# Altium schematic sheet Resistive 1.SchDoc
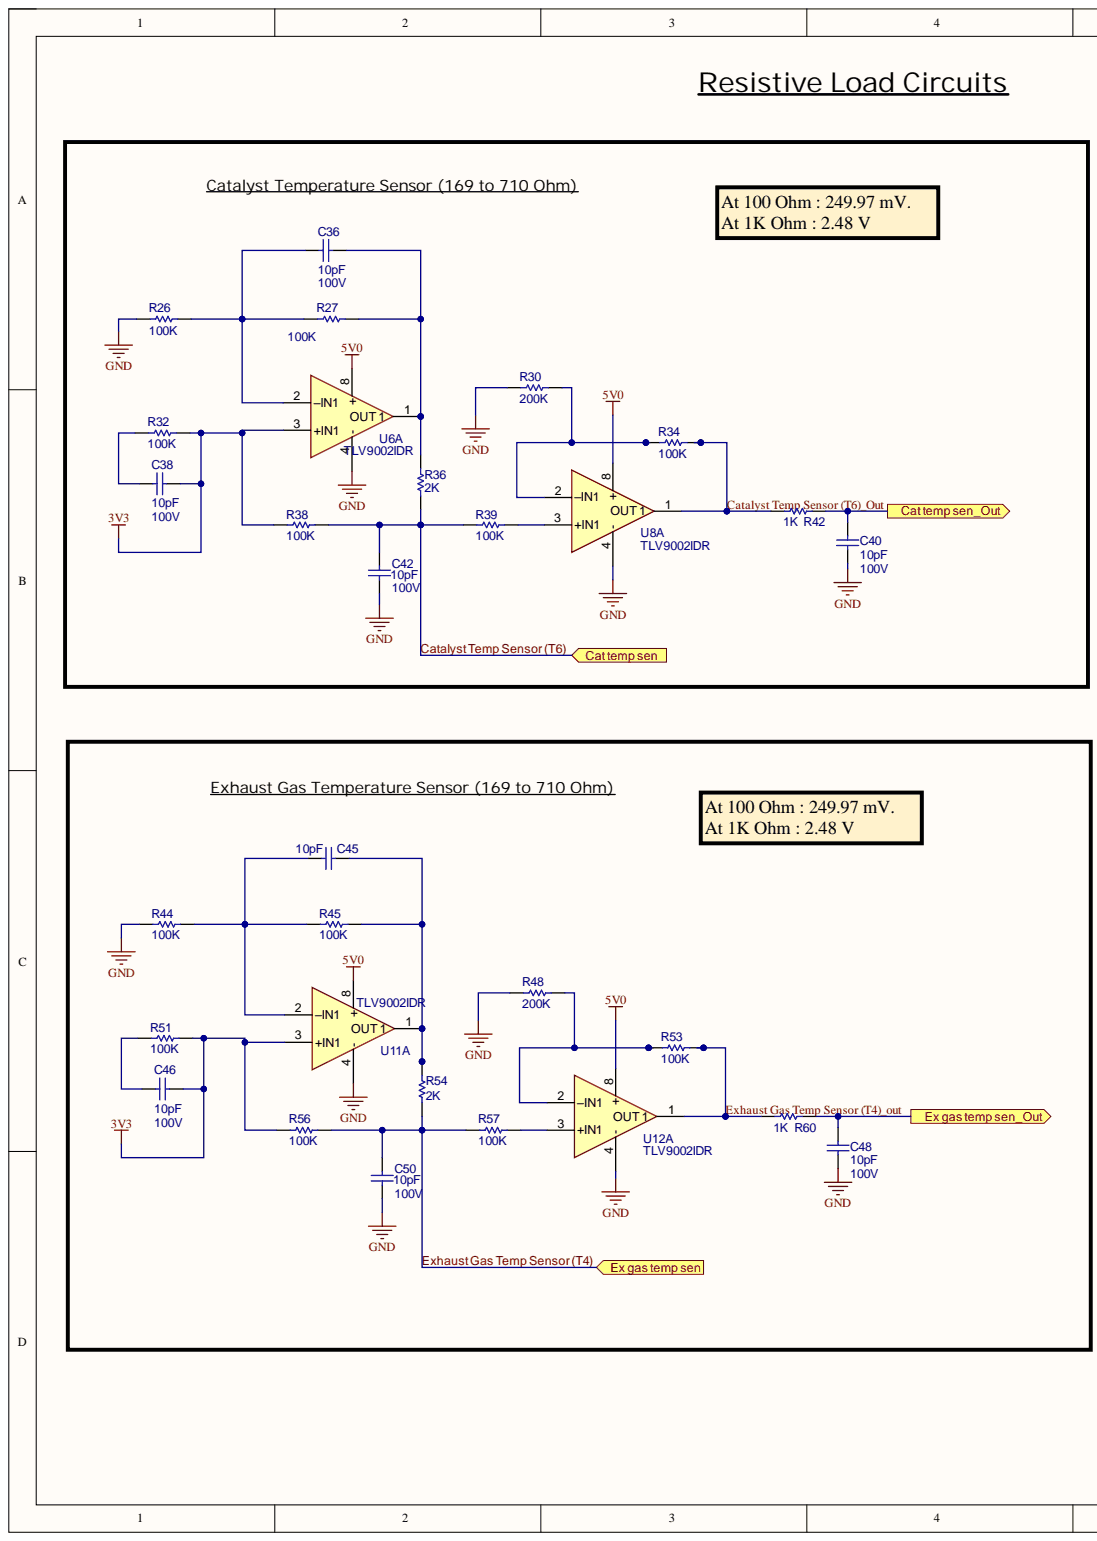
[diagram p0: Resistive 1.SchDoc, left]
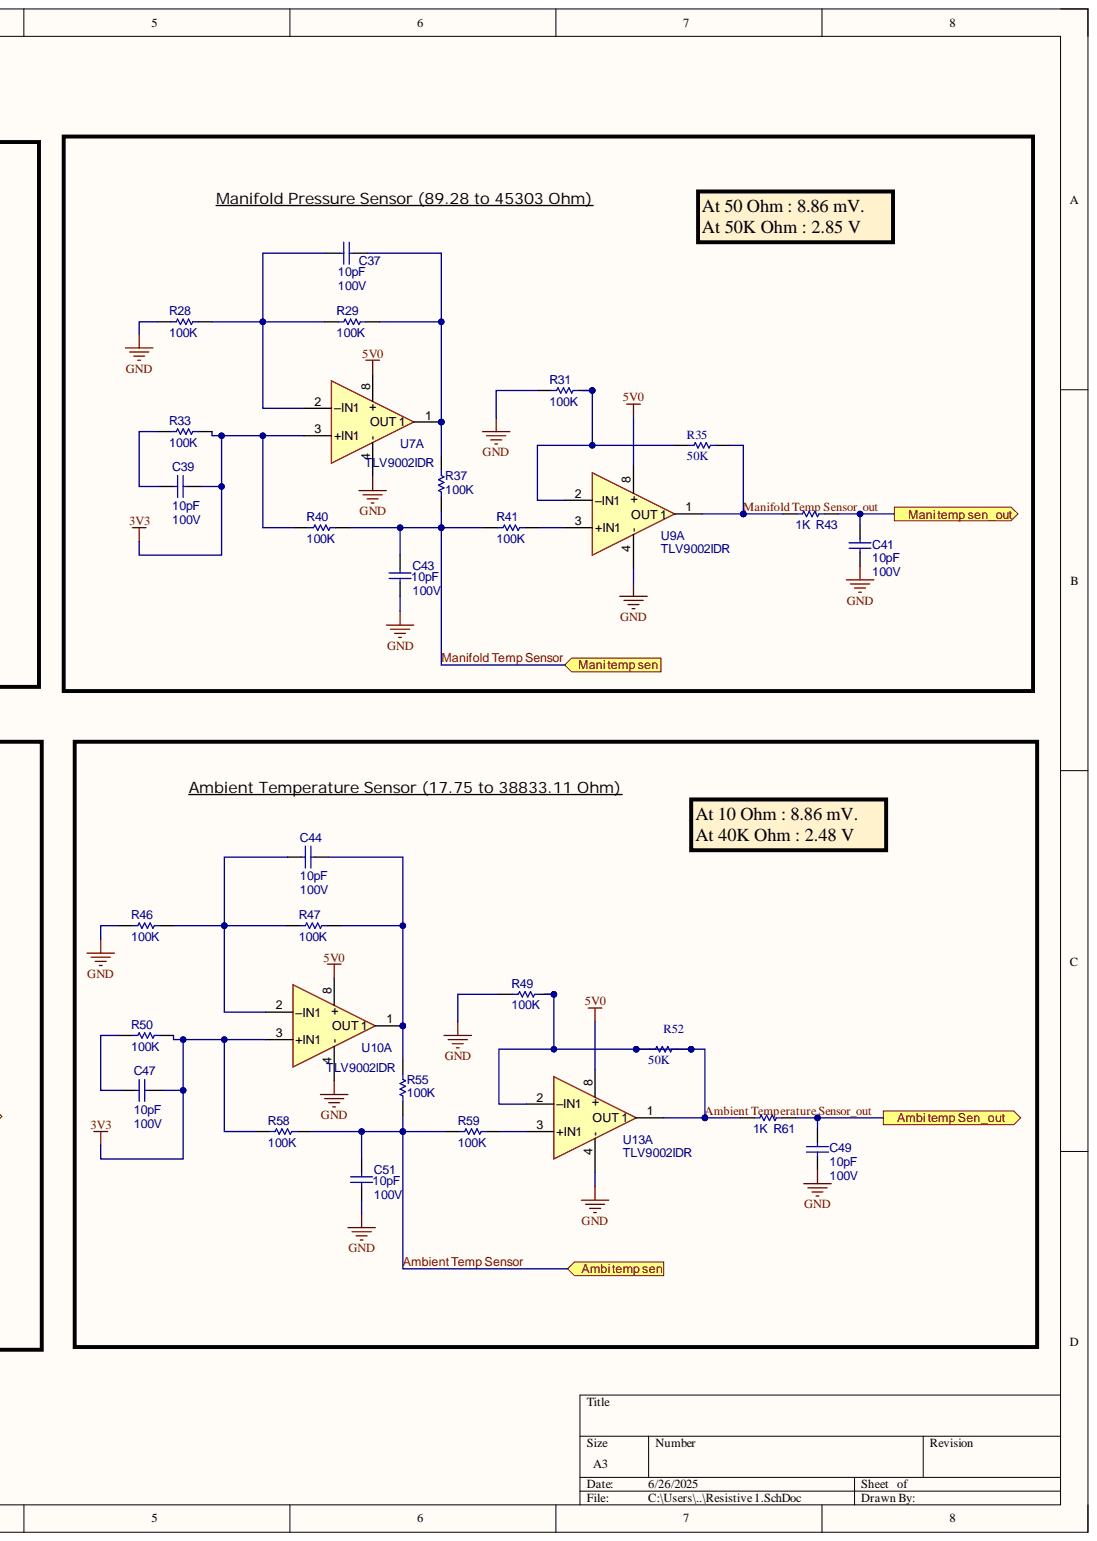
[diagram p1: Resistive 1.SchDoc, right]

## Parts
C36  10pF  Voltage: 100V  [CAP-0603]
C37  10pF  Voltage: 100V  [CAP-0603]
C38  10pF  Voltage: 100V  [CAP-0603]
C39  10pF  Voltage: 100V  [CAP-0603]
C40  10pF  Voltage: 100V  [CAP-0603]
C41  10pF  Voltage: 100V  [CAP-0603]
C42  10pF  Voltage: 100V  [CAP-0603]
C43  10pF  Voltage: 100V  [CAP-0603]
C44  10pF  Voltage: 100V  [CAP-0603]
C45  10pF  [CAP-0603]
C46  10pF  Voltage: 100V  [CAP-0603]
C47  10pF  Voltage: 100V  [CAP-0603]
C48  10pF  Voltage: 100V  [CAP-0603]
C49  10pF  Voltage: 100V  [CAP-0603]
C50  10pF  Voltage: 100V  [CAP-0603]
C51  10pF  Voltage: 100V  [CAP-0603]
R26  100K  [RES-0603]
R27  100K  [RES-0603]
R28  100K  [RES-0603]
R29  100K  [RES-0603]
R30  200K  [RES-0603]
R31  100K  [RES-0603]
R32  100K  [RES-0603]
R33  100K  [RES-0603]
R34  100K  [RES-0603]
R35  50K  [RES_0805]
R36  2K  [RES-0603]
R37  100K  [RES-0603]
R38  100K  [RES-0603]
R39  100K  [RES-0603]
R40  100K  [RES-0603]
R41  100K  [RES-0603]
R42  1K  [RES-0603]
R43  1K  [RES-0603]
R44  100K  [RES-0603]
R45  100K  [RES-0603]
R46  100K  [RES-0603]
R47  100K  [RES-0603]
R48  200K  [RES-0603]
R49  100K  [RES-0603]
R50  100K  [RES-0603]
R51  100K  [RES-0603]
R52  50K  [RES_0805]
R53  100K  [RES-0603]
R54  2K  [RES-0603]
R55  100K  [RES-0603]
R56  100K  [RES-0603]
R57  100K  [RES-0603]
R58  100K  [RES-0603]
R59  100K  [RES-0603]
R60  1K  [RES-0603]
R61  1K  [RES-0603]
U6  TLV9002IDR  [SOIC127P599X175-8N]  (part A)
U7  TLV9002IDR  [SOIC127P599X175-8N]  (part A)
U8  TLV9002IDR  [SOIC127P599X175-8N]  (part A)
U9  TLV9002IDR  [SOIC127P599X175-8N]  (part A)
U10  TLV9002IDR  [SOIC127P599X175-8N]  (part A)
U11  TLV9002IDR  [SOIC127P599X175-8N]  (part A)
U12  TLV9002IDR  [SOIC127P599X175-8N]  (part A)
U13  TLV9002IDR  [SOIC127P599X175-8N]  (part A)

## Ports
Ambi temp sen, Ambi temp Sen_out, Cat temp sen, Cat temp sen_Out, Ex gas temp sen, Ex gas temp sen_Out, Mani temp sen, Mani temp sen_out

## Nets
3V3: C38.1 C39.1 C46.1 C47.1 R32.1 R33.1 R38.2 R40.2 R50.1 R51.1 R56.2 R58.2 U6.3(+IN1) U7.3(+IN1) U10.3(+IN1) U11.3(+IN1)
5V0: U6.8(+) U7.8(+) U8.8(+) U9.8(+) U10.8(+) U11.8(+) U12.8(+) U13.8(+)
GND: C40.2 C41.2 C42.2 C43.2 C48.2 C49.2 C50.2 C51.2 R26.2 R28.2 R30.2 R31.2 R44.2 R46.2 R48.2 R49.2 U6.4(-) U7.4(-) U8.4(-) U9.4(-) U10.4(-) U11.4(-) U12.4(-) U13.4(-)
Ambi temp Sen_out: C49.1 R61.1 ; port Ambi temp Sen_out
Ambient Temp Sensor: C51.1 R55.2 R58.1 R59.2 ; port Ambi temp sen
Ambient Temperature Sensor_out: R49.1 R52.1 R52.2 R61.2 U13.1(OUT_1) U13.2(–IN1)
Cat temp sen_Out: C40.1 R42.1 ; port Cat temp sen_Out
Catalyst Temp Sensor (T6): C42.1 R36.1 R38.1 R39.2 ; port Cat temp sen
Catalyst Temp Sensor (T6)_Out: R34.1 R42.2 U8.1(OUT_1)
Ex gas temp sen_Out: C48.1 R60.1 ; port Ex gas temp sen_Out
Exhaust Gas Temp Sensor (T4): C50.1 R54.1 R56.1 R57.2 ; port Ex gas temp sen
Exhaust Gas Temp Sensor (T4)_out: R53.1 R60.2 U12.1(OUT_1)
Mani temp sen_out: C41.1 R43.1 ; port Mani temp sen_out
Manifold Temp Sensor: C43.1 R37.2 R40.1 R41.2 ; port Mani temp sen
Manifold Temp Sensor_out: R35.2 R43.2 U9.1(OUT_1)
NetC36_1: C36.1 R27.1 R36.2 U6.1(OUT_1)
NetC36_2: C36.2 R26.1 R27.2 U6.2(–IN1)
NetC37_1: C37.1 R29.1 R37.1 U7.1(OUT_1)
NetC37_2: C37.2 R28.1 R29.2 U7.2(–IN1)
NetC38_2: C38.2 R32.2
NetC39_2: C39.2 R33.2
NetC44_1: C44.1 R47.1 R55.1 U10.1(OUT_1)
NetC44_2: C44.2 R46.1 R47.2 U10.2(–IN1)
NetC45_1: C45.1 R45.1 R54.2 U11.1(OUT_1)
NetC45_2: C45.2 R44.1 R45.2 U11.2(–IN1)
NetC46_2: C46.2 R51.2
NetC47_2: C47.2 R50.2
NetR30_1: R30.1 R34.2 U8.2(–IN1)
NetR31_1: R31.1 R35.1 U9.2(–IN1)
NetR39_1: R39.1 U8.3(+IN1)
NetR41_1: R41.1 U9.3(+IN1)
NetR48_1: R48.1 R53.2 U12.2(–IN1)
NetR57_1: R57.1 U12.3(+IN1)
NetR59_1: R59.1 U13.3(+IN1)

## Library data of the parts
C36 C37 C39 C42-C46 C50 C51 = CAP: CAP CER 10PF 100V C0G/NP0 0603,C0603X100J1GACAUTO; Manufacturer: Murata Electronics; Part Number: GCM1885C2A100JA16D; Tolerance: ±1%; Component Kind: Standard; Library Name: Daughter_board_SE3_V1.SCHLIB; Package: 0603; Technology: SMT; Value: 10pF
  C45: Voltage: 100V
C38 = CAP: CAP CER 10PF 100V C0G/NP0 0603, C0603X100J1GACAUTO; Manufacturer: Murata Electronics; Part Number: GCM1885C2A100JA16D; Tolerance: ±1%; Component Kind: Standard; Library Name: Daughter_board_SE3_V1.SCHLIB; Package: 0603; Technology: SMT; Value: 10pF
C40 C41 C47-C49 = CAP: CAP CER 10PF 100V C0G/NP0 0603; Manufacturer: Murata Electronics; Part Number: GCM1885C2A100JA16D; Tolerance: ±1%; Component Kind: Standard; Library Name: Daughter_board_SE3_V1.SCHLIB; Package: 0603; Technology: SMT; Value: 10pF
R26-R29 R31-R34 R37-R41 R44-R47 R49-R51 R53 R55-R59 = RES-2: RES SMD 100K OHM 1% 1/10W 0603,MCT06030C1003FP500; Manufacturer: YAGEO; Part Number: AC0603FR-07100KL; Power Rating: 100mW; Component Kind: Standard; Library Name: Daughter_board_SE3_V1.SCHLIB; Package: 0603; Tolerance: ±1%; Value: 100K
R30 R48 = RES-2: RES SMD 200K OHM 1% 1/10W 0603,  CHV0603AFX-2003ELF; Manufacturer: YAGEO; Part Number: AC0603FR-07200KL; Power Rating: 100mW; Component Kind: Standard; Library Name: Daughter_board_SE3_V1.SCHLIB; Package: 0603; Tolerance: ±1%; Value: 200K
R35 R52 = Res_50k_0.5W_0.1%_0805: Res_50k_0.5W_0.1%_0805, MFP50SBBE52-50K; Component Kind: Standard; Library Name: GENSET CONTROLLER BETA V01.SCHLIB
R36 R54 = RES-2_32: RES SMD 2K OHM 1% 1/10W 0603,RCA06032K00FKEA; Manufacturer: YAGEO; Part Number: AC0603FR-072KL; Power Rating: 100mW; Component Kind: Standard; Package: 0603; Tolerance: ±1%; Value: 2K; Library Name: GENSET CONTROLLER BETA V01.SCHLIB
R42 R43 R60 R61 = RES-2: RES SMD 1K OHM 1% 1/10W 0603; Manufacturer: YAGEO; Part Number: AC0603FR-071KL; Power Rating: 100mW; Component Kind: Standard; Library Name: Daughter_board_SE3_V1.SCHLIB; Package: 0603; Tolerance: ±1%; Value: 1K
U6-U13 = TLV9002IDR: IC OPAMP GP 2 CIRCUIT 8SOIC; Manufacturer: Texas Instruments; Component Kind: Standard; Part Number: TLV9002IDR; Library Name: GENSET CONTROLLER BETA V1.SCHLIB
